# Altium schematic sheet Power.SchDoc
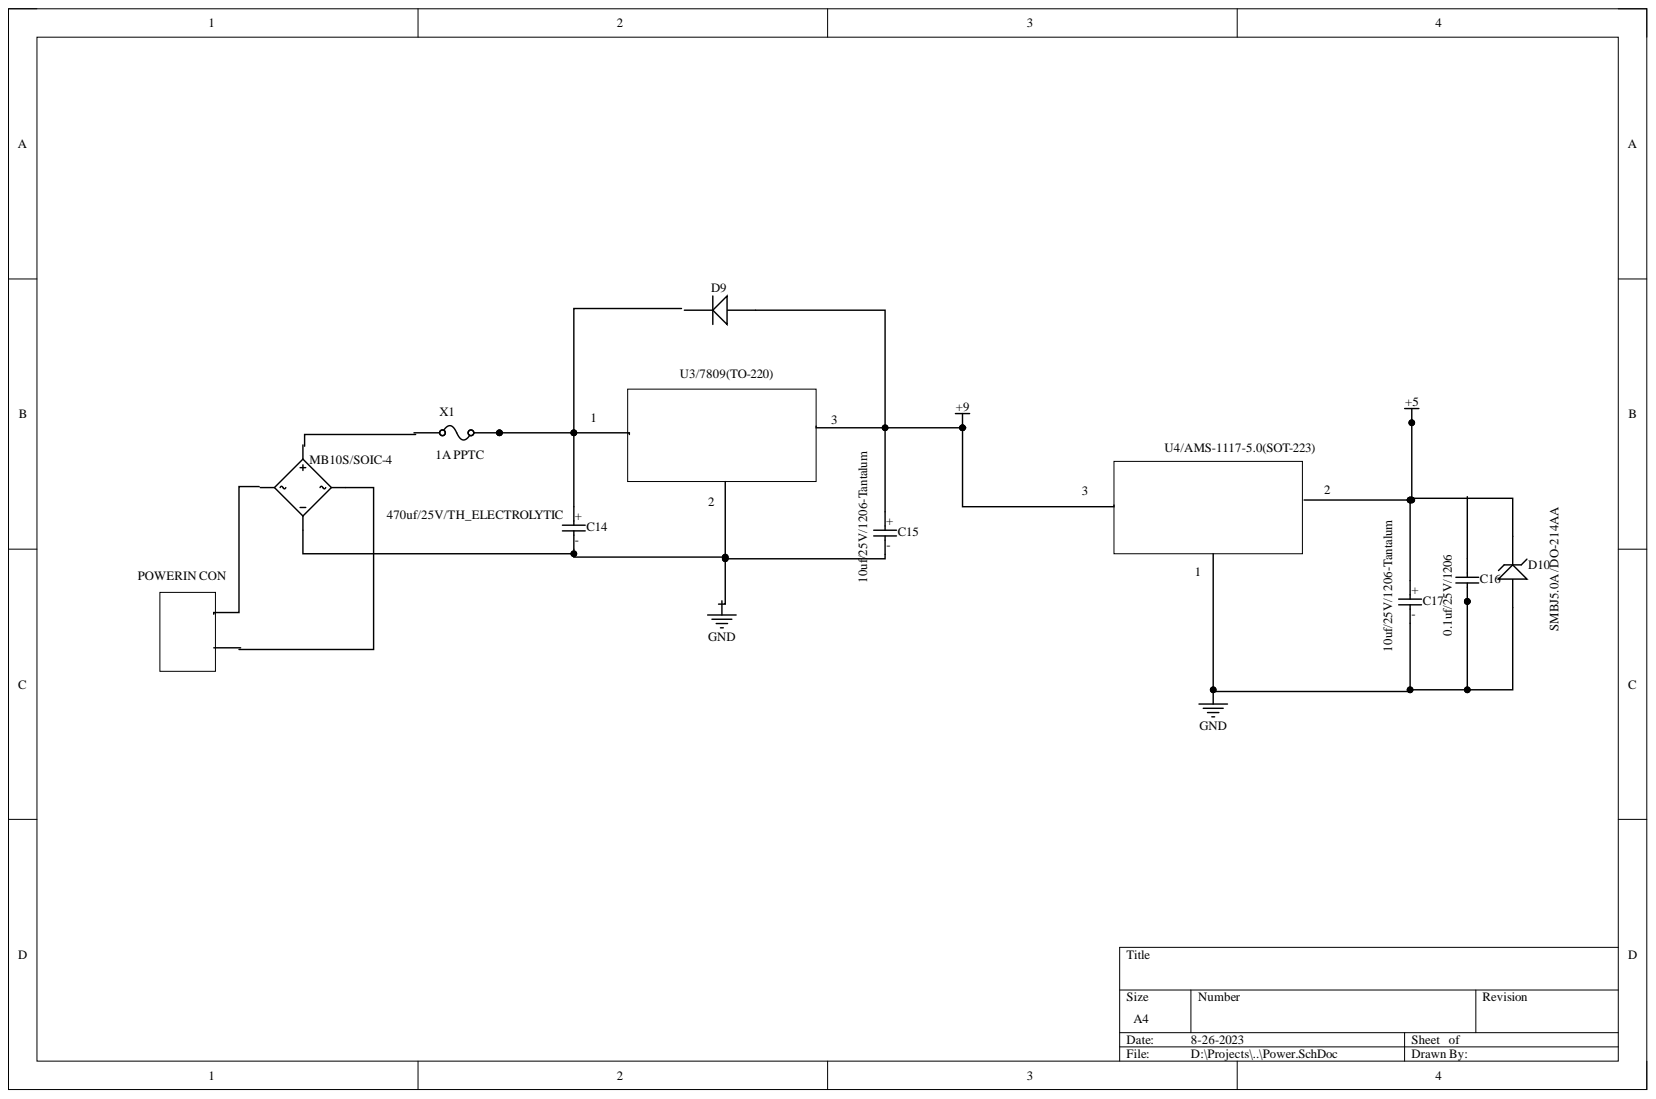
[diagram p0: Power.SchDoc]

## Parts
C14  value 470uf/25V/TH_ELECTROLYTIC  (hidden comment: Capacitor)
C15  value 10uf/25V/1206-Tantalum  (hidden comment: Capacitor)
C16  value 0.1uf/25V/1206  (hidden comment: Capacitor)
C17  value 10uf/25V/1206-Tantalum  (hidden comment: Capacitor)
D9  Diode  (value not drawn)
D10  BV: SMBJ5.0A /DO-214AA  (hidden comment: Zener Diode)
MB10S/SOIC-4  Four Diodes Bridge Rectifier  (value not drawn)
X1  CURRENT: 1A PPTC  (hidden comment: Fuse)

## Nets
+5: C17.2 D10.2
+9: D9.1
GND: C16.1 C17.1 D10.1
NetC14_1: C14.1 C15.1 MB10S/SOIC-4.4
NetC14_2: C14.2 X1.2

Not connected: C15 2; C16 2; D9 2; MB10S/SOIC-4 1 2 3; X1 1

## Library data of the parts
C14-C17 = Capacitor: SimulationGenericComponent: True; Component Kind: Standard; Description: Capacitor; Library Name: Simulation Generic Components.IntLib; Simulation: C_Capacitor_Default
D9 = Diode: AF: 1; BV: 0; BV_MAX: 1e99; EG: 1.11; FC: 0.5; IBV: 0.001; IS: 1e-14; MJSW: 0.33; NTUN: 30; SimulationGenericComponent: True; TNOM: 27; XTI: 3; CJO: 0; CJP: 0; Component Kind: Standard; CTA: 0; CTP: 0; Description: Diode; FCS: 0.5; FV_MAX: 1e99; IKF: 0; IKR: 0; ISR: 1e-14; JSW: 0; JTUN: 0; JTUNSW: 0; KEG: 1; KF: 0; LEVEL: 1; Library Name: Simulation Generic Components.IntLib; N: 1; NBV: 1; NR: 1; NS: 1; PHP: 1; RS: 0; Simulation: diode; TCV: 0; TLEV: 0; TLEVC: 0; TM1: 0; TM2: 0; TPB: 0; TPHP: 0; TRS: 0; TRS2: 0; TT: 0; TTT1: 0; TTT2: 0; VJ: 1; XTITUN: 3
D10 = Zener Diode: AF: 1; BV_MAX: 1e99; CJO: 0; EG: 1.11; FC: 0.5; IBV: 0.001; IS: 1e-14; MJSW: 0.33; NTUN: 30; SimulationGenericComponent: True; TNOM: 27; XTI: 3; CJP: 0; Component Kind: Standard; CTA: 0; CTP: 0; Description: Zener Diode; FCS: 0.5; FV_MAX: 1e99; IKF: 0; IKR: 0; ISR: 1e-14; JSW: 0; JTUN: 0; JTUNSW: 0; KEG: 1; KF: 0; LEVEL: 1; Library Name: Simulation Generic Components.IntLib; N: 1; NBV: 1; NR: 1; NS: 1; PHP: 1; RS: 0; Simulation: diode; TCV: 0; TLEV: 0; TLEVC: 0; TM1: 0; TM2: 0; TPB: 0; TPHP: 0; TRS: 0; TRS2: 0; TT: 0; TTT1: 0; TTT2: 0; VJ: 1; XTITUN: 3
MB10S/SOIC-4 = Bridge Rectifier: AF: 1; BV: 0; BV_MAX: 1e99; EG: 1.11; FC: 0.5; IBV: 100p; IS: 10f; MJSW: 0.33; NTUN: 30; SimulationGenericComponent: True; temp: 27; XTI: 3; area: 1; CJO: 0; CJP: 0; Component Kind: Standard; CTA: 0; CTP: 0; Description: Four Diodes Bridge Rectifier; dtemp: 0; FCS: 0.5; FV_MAX: 1e99; IBVL: 0; IKF: 0; IKR: 0; ISR: 0; JSW: 0; JTUN: 0; JTUNSW: 0; KEG: 1; KF: 0; Library Name: Simulation Generic Components.IntLib; M: 0.5; N: 1; NBV: 1; NBVL: 1; NR: 1; NS: 1; PHP: 1; pj: 1; RS: 0; Simulation: Bridge_Rectifier; TBV1: 0; TBV2: 0; TCV: 0; TIKF: 0; TLEV: 0; TLEVC: 0; TM1: 0; TM2: 0; TNOM: 27; TPHP: 0; TRS1: 0; TRS2: 0; TT: 0; TTT1: 0; TTT2: 0; TVJ: 0; VJ: 1; XTITUN: 3
X1 = Fuse: RESISTANCE: 1m; SimulationGenericComponent: True; Component Kind: Standard; Description: Fuse; Library Name: Simulation Generic Components.IntLib; Simulation: FUSE
